# Altium schematic sheet 017_FlashPowerManage.SchDoc(017_FlashPowerManage)
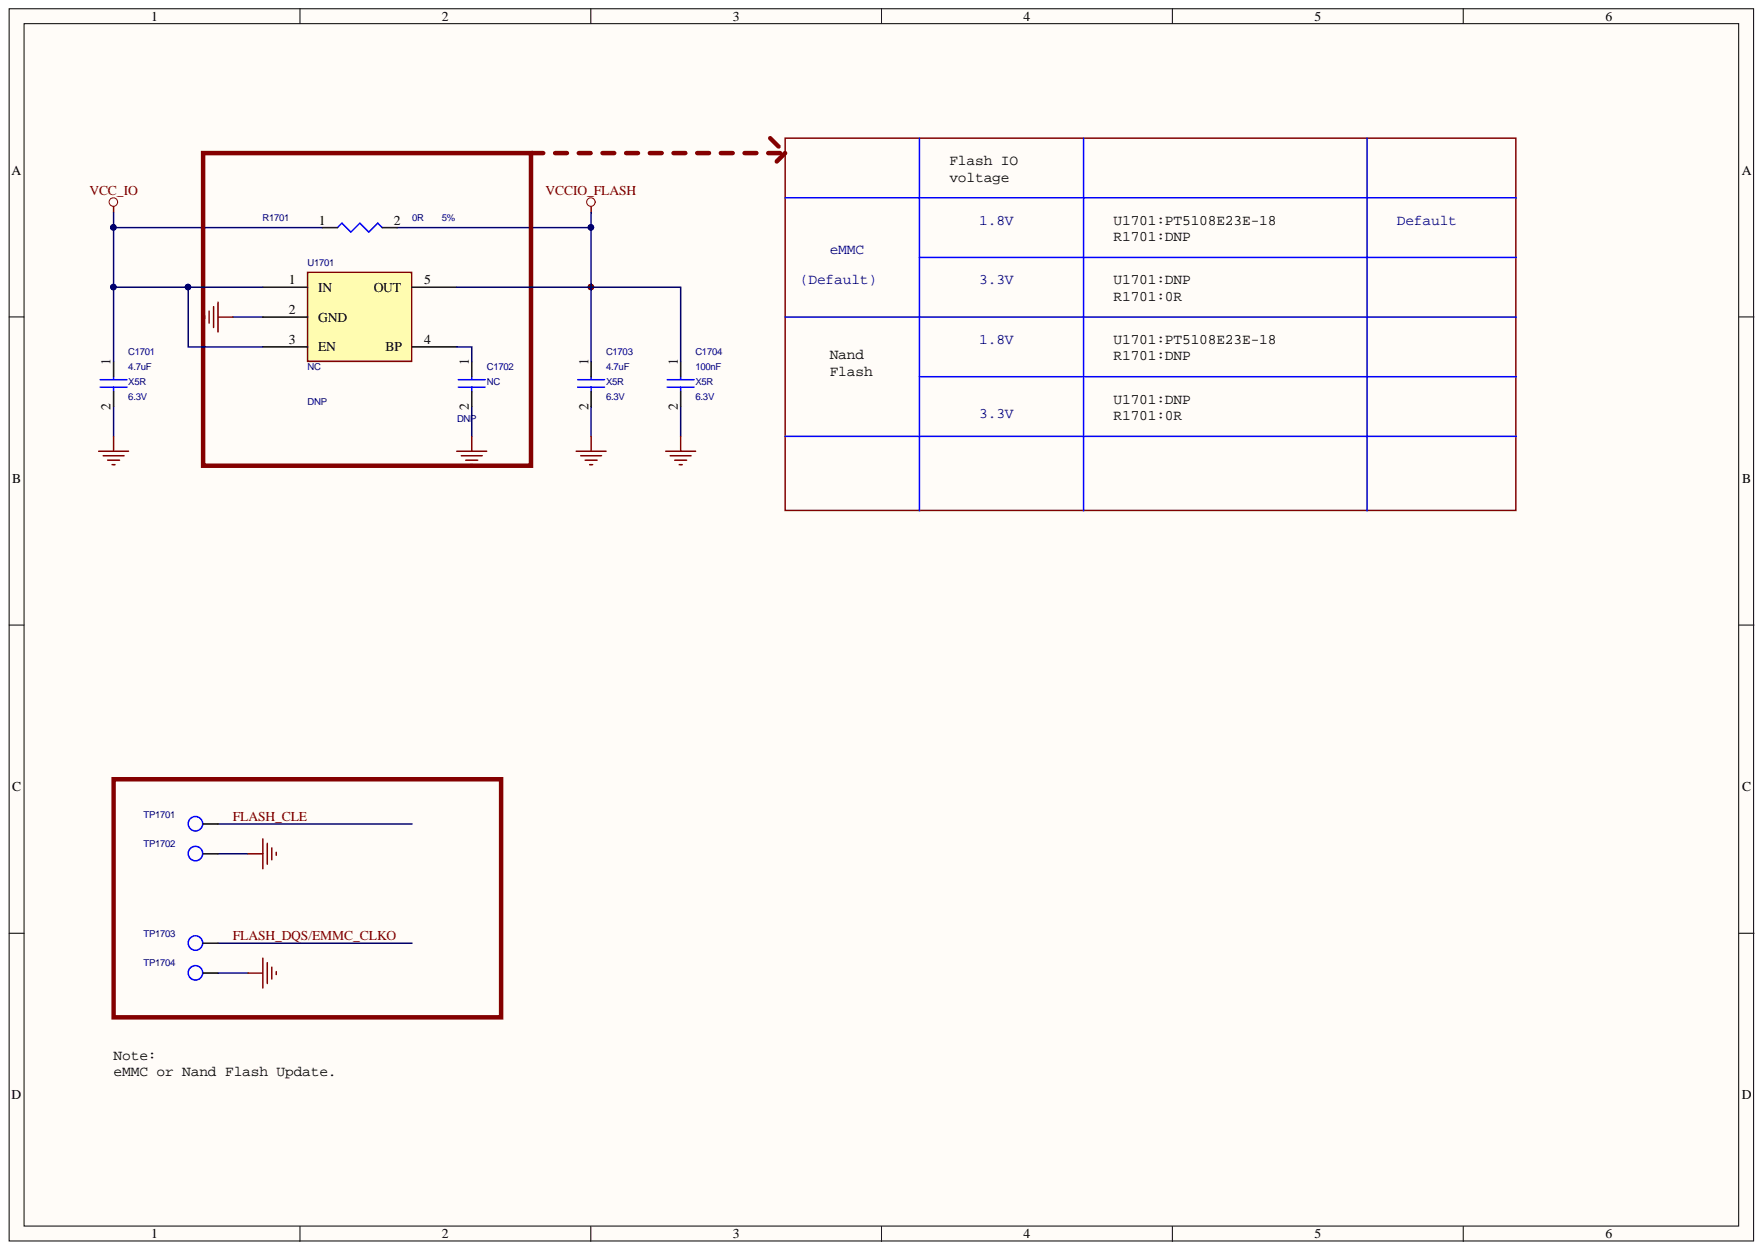
[diagram p0: 017_FlashPowerManage.SchDoc]

## Parts
C1701  4.7uF  Voltage: 6.3V  Dielectric: X5R  [C0603]
C1702  NC  Part Type: DNP  [C0402]
C1703  4.7uF  Voltage: 6.3V  Dielectric: X5R  [C0603]
C1704  100nF  Voltage: 6.3V  Dielectric: X5R  [C0402]
R1701  0R  [R0402]
TP1701  TP_0.7  [TEST]  (value not drawn)
TP1702  TP_0.7  [TEST]  (value not drawn)
TP1703  TP_0.7  [TEST]  (value not drawn)
TP1704  TP_0.7  [TEST]  (value not drawn)
U1701  NC  [SOT_23_5]

## Nets
GND: C1701.2 C1702.2 C1703.2 C1704.2 TP1702.1 TP1704.1 U1701.2(GND)
VCC_IO: C1701.1 R1701.1 U1701.1(IN) U1701.3(EN)
VCCIO_FLASH: C1703.1 C1704.1 R1701.2 U1701.5(OUT)
FLASH_CLE: TP1701.1
FLASH_DQS/EMMC_CLKO: TP1703.1
NetC1702_1: C1702.1 U1701.4(BP)

## Library data of the parts
C1701 C1703 = CAP NP_Dup5: cap,4.70uF,+/-10%,6.3V,X5R,C0603; Manufacturer: TDK; Tolerance: 10%; RK PN: C1608X5R0J475K080AB; Priority: A; Part Type: C0603; Manufacturer PN: C1608X5R0J475K080AB; Component Kind: Standard; C_Voltage: 6.3V; Created by: JJJ
C1702 = CAP NP_Dup5: Did Not Place.; RK PN: DNP0402; Priority: A; Number: 37; C_Voltage: 6.3V; Option: DNP; Manufacturer PN: DNP0402; Component Kind: Standard; Created by: JJJ
C1704 = CAP NP_Dup5: cap,100.00nF,+/-10%,6.3V,X5R,C0402; Manufacturer: TDK; Tolerance: 10%; RK PN: C1005X5R0J104K050BA; Priority: A; Number: 37; Part Type: C0402; Manufacturer PN: C1005X5R0J104K050BA; Component Kind: Standard; C_Voltage: 6.3V; Created by: JJJ
R1701 = RESISTOR_Dup1: 哥棨슌훡롯量,0R,+/-5%,R0402,1/16W.; Manufacturer: YAGEO; Wattage: 1/16W; Tolerance: 5%; RK PN: RC0402JR-070RL; Priority: A; Part Type: 哥棨슌훡롯量; Manufacturer PN: RC0402JR-070RL; Component Kind: Standard; Created by: YTL
TP1701-TP1704 = TP: Test Point 0.7mm; RK PN: TESTPONIT_0R7; Priority: A; Part Type: TP; Created Date: 20140617; Component Kind: Standard; Created by: CZD
U1701 = LDO_PT5108: High-PSRR 500mA LDO; Manufacturer: Powtech?심쒔肩鑒?; RK PN: PT5108E23E-18; Priority: A; Option: DNP; Part Type: LDO; Manufacturer PN: PT5108E23E-18; Component Kind: Standard; Created by: JJJ
